# Altium schematic sheet [4]-USB.SchDoc
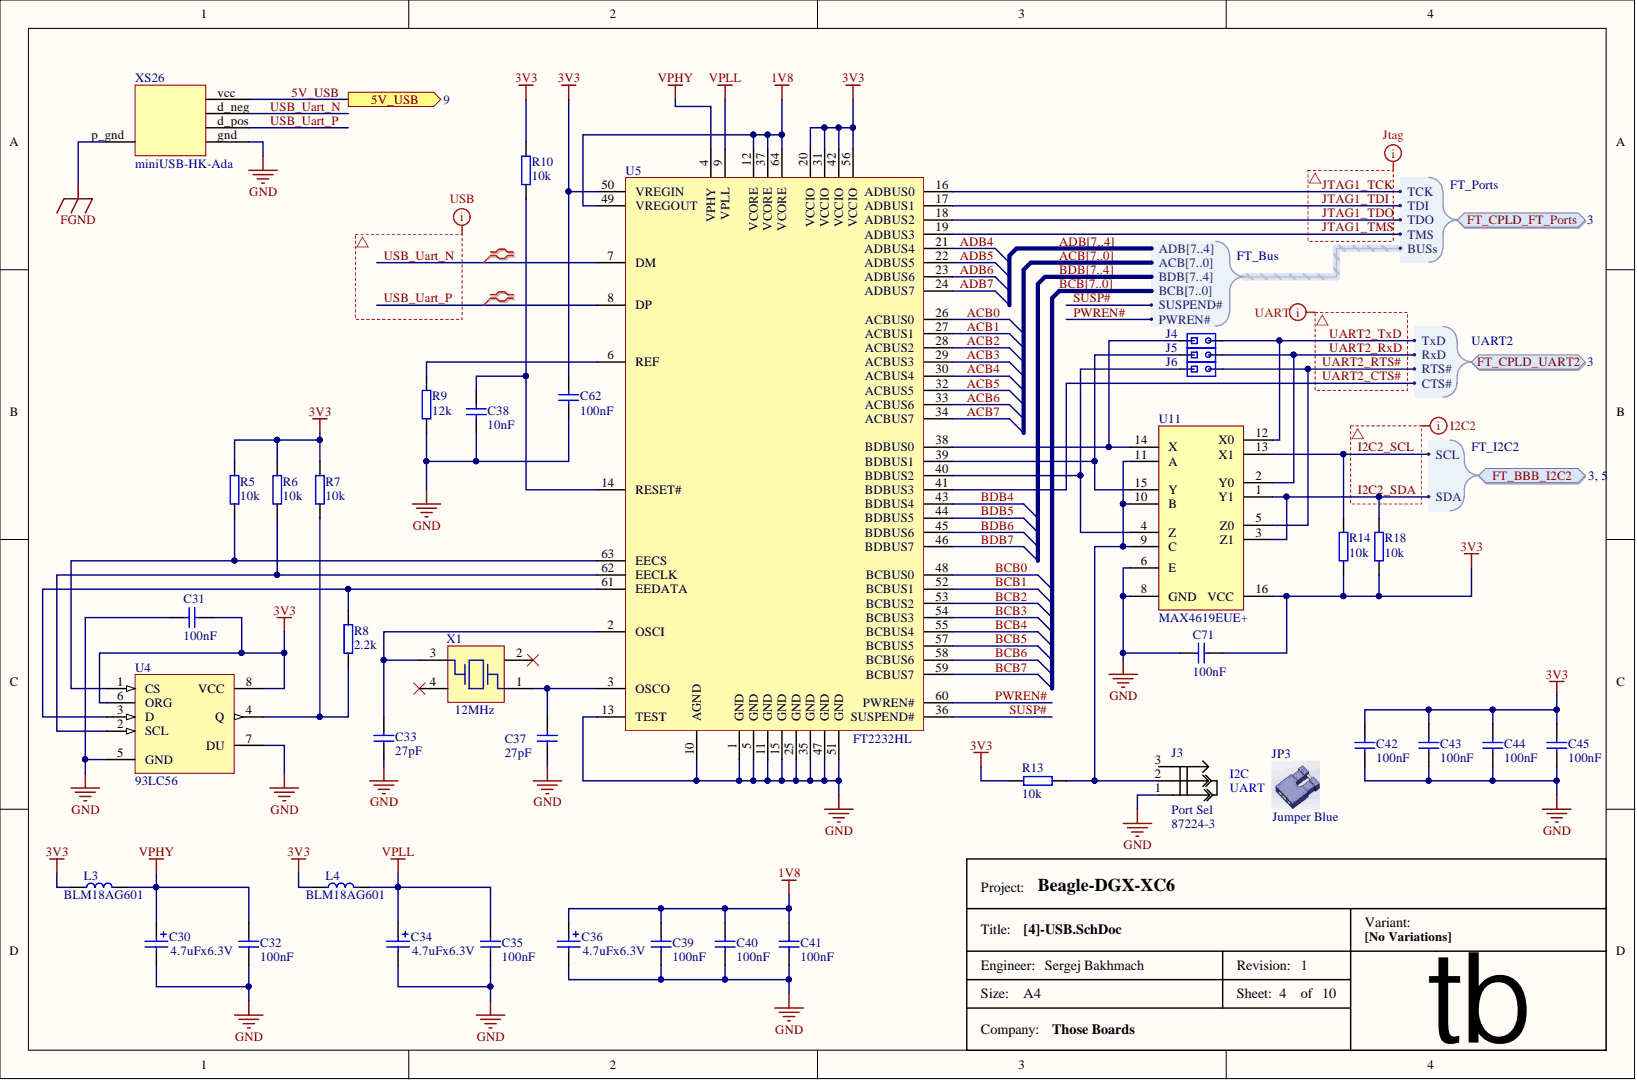
[diagram p0: [4]-USB.SchDoc]

## Parts
C30  value 4.7uFx6.3V  [CAP TAN 0805 2012 SIZE R]  (hidden comment: uF)
C31  value 100nF  [C0603]  (hidden comment: CAP)
C32  value 100nF  [C0603]  (hidden comment: CAP)
C33  value 27pF  [C0603]  (hidden comment: CAP)
C34  value 4.7uFx6.3V  [CAP TAN 0805 2012 SIZE R]  (hidden comment: uF)
C35  value 100nF  [C0603]  (hidden comment: CAP)
C36  value 4.7uFx6.3V  [CAP TAN 0805 2012 SIZE R]  (hidden comment: uF)
C37  value 27pF  [C0603]  (hidden comment: CAP)
C38  value 10nF  [C0603]  (hidden comment: CAP)
C39  value 100nF  [C0603]  (hidden comment: CAP)
C40  value 100nF  [C0603]  (hidden comment: CAP)
C41  value 100nF  [C0603]  (hidden comment: CAP)
C42  value 100nF  [C0603]  (hidden comment: CAP)
C43  value 100nF  [C0603]  (hidden comment: CAP)
C44  value 100nF  [C0603]  (hidden comment: CAP)
C45  value 100nF  [C0603]  (hidden comment: CAP)
C62  value 100nF  [C0603]  (hidden comment: CAP)
C71  value 100nF  [C0603]  (hidden comment: CAP)
J3  Port Sel  value 87224-3  Manufacturer Part: 87224-3  [Jumper_3pin]
J4  (no value)  [Jumper_smd_d3]  (no BOM)
J5  (no value)  [Jumper_smd_d3]  (no BOM)
J6  (no value)  [Jumper_smd_d3]  (no BOM)
JP3  value Jumper Blue  Supplier Part: Jumper  (hidden comment: VCC_sel)  (mechanical)
L3  value BLM18AG601  [ferrite_bead_0603]  (hidden comment: BLM18PG221SN1D)
L4  value BLM18AG601  [ferrite_bead_0603]  (hidden comment: BLM18PG221SN1D)
R5  value 10k  [0603_RESISTOR]  (hidden comment: Resistor)
R6  value 10k  [0603_RESISTOR]  (hidden comment: Resistor)
R7  value 10k  [0603_RESISTOR]  (hidden comment: Resistor)
R8  value 2.2k  [0603_RESISTOR]  (hidden comment: Resistor)
R9  value 12k  [0603_RESISTOR]  (hidden comment: Resistor)
R10  value 10k  [0603_RESISTOR]  (hidden comment: Resistor)
R13  value 10k  [0603_RESISTOR]  (hidden comment: Resistor)
R14  value 10k  [0603_RESISTOR]  (hidden comment: Resistor)
R18  value 10k  [0603_RESISTOR]  (hidden comment: Resistor)
U4  value 93LC56  [SOIC-8 150 Mil]  (hidden comment: 93xCxx)
U5  FT2232HL  [LQFP64]
U11  MAX4619EUE+  [TSSOP-16]
X1  value 12MHz  [OSC_CX3225GB]  (hidden comment: OSC_CX3225GB)
XS26  value miniUSB-HK-Ada  Manufacturer Part: miniUSB-HK-Ada  [MiniUSB_Conn_2types]  (hidden comment: miniUSB_conn)

## Ports
5V_USB, FT_BBB_I2C2, FT_BBB_I2C2.SCL, FT_BBB_I2C2.SDA, FT_CPLD_FT_Ports, FT_CPLD_FT_PORTS.BUSS.ACB0, FT_CPLD_FT_PORTS.BUSS.ACB1, FT_CPLD_FT_PORTS.BUSS.ACB2, FT_CPLD_FT_PORTS.BUSS.ACB3, FT_CPLD_FT_PORTS.BUSS.ACB4, FT_CPLD_FT_PORTS.BUSS.ACB5, FT_CPLD_FT_PORTS.BUSS.ACB6, FT_CPLD_FT_PORTS.BUSS.ACB7, FT_CPLD_FT_Ports.BUSs.ACB[7..0], FT_CPLD_FT_PORTS.BUSS.ADB4, FT_CPLD_FT_PORTS.BUSS.ADB5, FT_CPLD_FT_PORTS.BUSS.ADB6, FT_CPLD_FT_PORTS.BUSS.ADB7, FT_CPLD_FT_Ports.BUSs.ADB[7..4], FT_CPLD_FT_PORTS.BUSS.BCB0, FT_CPLD_FT_PORTS.BUSS.BCB1, FT_CPLD_FT_PORTS.BUSS.BCB2, FT_CPLD_FT_PORTS.BUSS.BCB3, FT_CPLD_FT_PORTS.BUSS.BCB4, FT_CPLD_FT_PORTS.BUSS.BCB5, FT_CPLD_FT_PORTS.BUSS.BCB6, FT_CPLD_FT_PORTS.BUSS.BCB7, FT_CPLD_FT_Ports.BUSs.BCB[7..0], FT_CPLD_FT_PORTS.BUSS.BDB4, FT_CPLD_FT_PORTS.BUSS.BDB5, FT_CPLD_FT_PORTS.BUSS.BDB6, FT_CPLD_FT_PORTS.BUSS.BDB7, FT_CPLD_FT_Ports.BUSs.BDB[7..4], FT_CPLD_FT_Ports.BUSs.PWREN#, FT_CPLD_FT_Ports.BUSs.SUSPEND#, FT_CPLD_FT_Ports.TCK, FT_CPLD_FT_Ports.TDI, FT_CPLD_FT_Ports.TDO, FT_CPLD_FT_Ports.TMS, FT_CPLD_UART2, FT_CPLD_UART2.CTS#, FT_CPLD_UART2.RTS#, FT_CPLD_UART2.RxD, FT_CPLD_UART2.TxD

## Nets
1V8: C36.1 C39.2 C40.2 C41.2 U5.12(VCORE) U5.37(VCORE) U5.49(VREGOUT) U5.64(VCORE)
3V3: C31.1 C42.2 C43.2 C44.2 C45.2 C62.2 C71.1 L3.1 L4.1 R5.2 R6.2 R7.2 R10.2 R13.2 R14.1 R18.1 U4.6(ORG) U4.8(VCC) U5.20(VCCIO) U5.31(VCCIO) U5.42(VCCIO) U5.50(VREGIN) U5.56(VCCIO) U11.16(VCC)
FGND: XS26.p_gnd
GND: C30.2 C31.2 C32.1 C33.1 C34.2 C35.1 C36.2 C37.1 C38.1 C39.1 C40.1 C41.1 C42.1 C43.1 C44.1 C45.1 C62.1 C71.2 J3.1 R9.1 U4.5(GND) U4.7(DU) U5.1(GND) U5.5(GND) U5.10(AGND) U5.11(GND) U5.13(TEST) U5.15(GND) U5.25(GND) U5.35(GND) U5.47(GND) U5.51(GND) U11.6(E) U11.8(GND) XS26.gnd
VPHY: C30.1 C32.2 L3.2 U5.4(VPHY)
VPLL: C34.1 C35.2 L4.2 U5.9(VPLL)
5V_USB: XS26.vcc ; port 5V_USB
ACB0: U5.26(ACBUS0) ; port FT_CPLD_FT_Ports  [also labelled ACB]
ACB1: U5.27(ACBUS1) ; port FT_CPLD_FT_Ports  [also labelled ACB]
ACB2: U5.28(ACBUS2) ; port FT_CPLD_FT_Ports  [also labelled ACB]
ACB3: U5.29(ACBUS3) ; port FT_CPLD_FT_Ports  [also labelled ACB]
ACB4: U5.30(ACBUS4) ; port FT_CPLD_FT_Ports  [also labelled ACB]
ACB5: U5.32(ACBUS5) ; port FT_CPLD_FT_Ports  [also labelled ACB]
ACB6: U5.33(ACBUS6) ; port FT_CPLD_FT_Ports  [also labelled ACB]
ACB7: U5.34(ACBUS7) ; port FT_CPLD_FT_Ports  [also labelled ACB]
ADB4: U5.21(ADBUS4) ; port FT_CPLD_FT_Ports  [also labelled ADB]
ADB5: U5.22(ADBUS5) ; port FT_CPLD_FT_Ports  [also labelled ADB]
ADB6: U5.23(ADBUS6) ; port FT_CPLD_FT_Ports  [also labelled ADB]
ADB7: U5.24(ADBUS7) ; port FT_CPLD_FT_Ports  [also labelled ADB]
BCB0: U5.48(BCBUS0) ; port FT_CPLD_FT_Ports  [also labelled BCB]
BCB1: U5.52(BCBUS1) ; port FT_CPLD_FT_Ports  [also labelled BCB]
BCB2: U5.53(BCBUS2) ; port FT_CPLD_FT_Ports  [also labelled BCB]
BCB3: U5.54(BCBUS3) ; port FT_CPLD_FT_Ports  [also labelled BCB]
BCB4: U5.55(BCBUS4) ; port FT_CPLD_FT_Ports  [also labelled BCB]
BCB5: U5.57(BCBUS5) ; port FT_CPLD_FT_Ports  [also labelled BCB]
BCB6: U5.58(BCBUS6) ; port FT_CPLD_FT_Ports  [also labelled BCB]
BCB7: U5.59(BCBUS7) ; port FT_CPLD_FT_Ports  [also labelled BCB]
BDB4: U5.43(BDBUS4) ; port FT_CPLD_FT_Ports  [also labelled BDB]
BDB5: U5.44(BDBUS5) ; port FT_CPLD_FT_Ports  [also labelled BDB]
BDB6: U5.45(BDBUS6) ; port FT_CPLD_FT_Ports  [also labelled BDB]
BDB7: U5.46(BDBUS7) ; port FT_CPLD_FT_Ports  [also labelled BDB]
I2C2_SCL: R14.2 U11.13(X1) ; port FT_BBB_I2C2
I2C2_SDA: R18.2 U11.1(Y1) U11.3(Z1) ; port FT_BBB_I2C2
JTAG1_TCK: U5.16(ADBUS0) ; port FT_CPLD_FT_Ports
JTAG1_TDI: U5.17(ADBUS1) ; port FT_CPLD_FT_Ports
JTAG1_TDO: U5.18(ADBUS2) ; port FT_CPLD_FT_Ports
JTAG1_TMS: U5.19(ADBUS3) ; port FT_CPLD_FT_Ports
PWREN#: U5.60(PWREN#) ; port FT_CPLD_FT_Ports
SUSP#: U5.36(SUSPEND#) ; port FT_CPLD_FT_Ports
UART2_CTS#: U5.41(BDBUS3) ; port FT_CPLD_UART2
UART2_RTS#: J6.2 U11.5(Z0) ; port FT_CPLD_UART2
UART2_RxD: J5.2 U11.2(Y0) ; port FT_CPLD_UART2
UART2_TxD: J4.2 U11.12(X0) ; port FT_CPLD_UART2
USB_Uart_N: U5.7(DM) XS26.d_neg
USB_Uart_P: U5.8(DP) XS26.d_pos
NetC33_2: C33.2 U5.2(OSCI) X1.3
NetC37_2: C37.2 U5.3(OSCO) X1.1
NetC38_2: C38.2 R10.1 U5.14(RESET#)
NetJ3_2: J3.2 R13.1 U11.9(C) U11.10(B) U11.11(A)
NetJ4_1: J4.1 U5.38(BDBUS0) U11.14(X)
NetJ5_1: J5.1 U5.39(BDBUS1) U11.15(Y)
NetJ6_1: J6.1 U5.40(BDBUS2) U11.4(Z)
NetR5_1: R5.1 U4.1(CS) U5.63(EECS)
NetR6_1: R6.1 U4.2(SCL) U5.62(EECLK)
NetR7_1: R7.1 R8.1 U4.4(Q)
NetR8_2: R8.2 U4.3(D) U5.61(EEDATA)
NetR9_2: R9.2 U5.6(REF)
N00235:
N00236:
N00237:
N00238:

Not connected: J3 3; X1 2 4

## Library data of the parts
C30 C34 C36 = Cap_pol_tant: Manufacturer: AVX; Manufacturer Part: TAJR475K006RNJ; Type of Component: Tantalum Capacitor; Component Kind: Standard; Manufacturer Link: https://www.avx.com/prodinfo_productdetail.asp?I=81&K=search&C=&word=TAJR475K006RNJ&form=number&Number=; Package / Case: 0805; Supplier: mouser; Supplier Link: http://www.mouser.com/ProductDetail/AVX/TAJR475K006RNJ/?qs=sGAEpiMZZMuEN2agSAc2pmRv4r8cj6yFV16HxCaO%252bYg%3d; Supplier Part: 581-TAJR475K006RNJ; Library Name: RPI-VITA.IntLib
C31 C32 C35 C39-C45 C62 C71 = CAP: Manufacturer: Vishay / Vitramon; Manufacturer Part: VJ0603Y104JXJPW1BC; Type of Component: Ceramic Capacitor; Component Kind: Standard; Manufacturer Link: http://www.vishay.com/product?docid=28548; Package / Case: 0603; Supplier: mouser; Supplier Link: http://www.mouser.com/ProductDetail/Vishay/VJ0603Y104JXJPW1BC/?qs=%2fha2pyFaduidGoO0X7aK7Xgs%2fjxfhnLiK6SRw4Lz0%252bZK3PJ8DddTrQ%3d%3d; Supplier Part: 77-VJ0603Y104JXJPBC; Library Name: RPI-VITA.IntLib
C33 C37 = CAP: Manufacturer: Vishay / Vitramon; Manufacturer Part: VJ0603A270GXAPW1BC; Type of Component: Ceramic Capacitor; Component Kind: Standard; Manufacturer Link: http://www.vishay.com/product?docid=28548; Package / Case: 0603; Supplier: mouser; Supplier Link: http://www.mouser.com/ProductDetail/Vishay-Vitramon/VJ0603A270GXAPW1BC/?qs=sGAEpiMZZMs0AnBnWHyRQCv4vfTUG%2fvHq9VjSt3ox9g%3d; Supplier Part: 77-VJ0603A270GXAPBC; Library Name: RPI-VITA.IntLib
C38 = CAP: Manufacturer: Vishay / Vitramon; Manufacturer Part: VJ0603Y103KXACW1BC; Type of Component: Ceramic Capacitor; Component Kind: Standard; Manufacturer Link: http://www.vishay.com/product?docid=28548; Package / Case: 0603; Supplier: mouser; Supplier Link: http://www.mouser.com/ProductDetail/Vishay-Vitramon/VJ0603Y103KXACW1BC/?qs=sGAEpiMZZMs0AnBnWHyRQNbg85K4ab%2f3XqRx2jQHGsc%3d; Supplier Part: 77-VJ0603Y103KXACBC; Library Name: RPI-VITA.IntLib
J3 = jumper_3pin: Manufacturer: TE Connectivity / AMP; Type of Component: Jumper; Component Kind: Standard; Manufacturer Link: http://www.te.com/usa-en/product-87224-3.html#pdp-related-products-inner; Package / Case: Header 1 x 3; Supplier: mouser; Supplier Link: http://www.mouser.ee/ProductDetail/TE-Connectivity-AMP/87224-3/?qs=sGAEpiMZZMs%252bGHln7q6pm48SVpWlpfsErguO%2fp0873w%3d; Supplier Part: 571-872243; Library Name: RPI-VITA.IntLib
J4-J6 = jumper_2pin_pads: Library Name: RPI-VITA.IntLib
JP3 = jumper blue: Manufacturer: Great Electric; Manufacturer Part: Jumper Cap Shunts Short Circuit Cap; Package / Case: 2.54mm; Supplier: aliexpress.com; Supplier Link: http://www.aliexpress.com/item/500pcs-lot-Asssorted-Color-2-54mm-Standard-Circuit-Board-Jumper-Cap-Shunts-Short-Circuit-Cap-CGKCH119/32344305619.html?spm=2114.03010208.3.80.nPPmoy&ws_ab_test=searchweb201556_1,searchweb201644_1_505_506_503_504_502_10001_10002_10017_10010_10005_10011_10006_10003_10004_10009_10007_10008,searchweb201560_5,searchweb1451318400_-1,searchweb1451318411_6452&btsid=16d11777-edde-4755-b8b5-9adacb495b09; Type of Component: Jumper; Library Name: RPI-VITA.IntLib
L3 L4 = BLM18PG221SN1D: ferrite bead 0603; Manufacturer: Murata; Manufacturer Part: BLM18AG601SN1D; Type of Component: EMI Filter Beads; Component Kind: Standard; Manufacturer Link: http://search.murata.co.jp/Ceramy/CatalogAction.do?sHinnm=BLM11A601S&sNHinnm=BLM18AG601SN1&sNhin_key=BLM18AG601SN1D&sLang=en&sParam=BLM18AG601SN1D; Package / Case: 0603; Supplier: mouser; Supplier Link: http://www.mouser.com/ProductDetail/Murata/BLM18AG601SN1D/?qs=%2fha2pyFadugaXrdTm9z6ujoHK9ziqVaZDl9lDPSbK0ptDeQWgq1exg%3d%3d; Supplier Part: 81-BLM11A601S; Library Name: RPI-VITA.IntLib
R5-R7 R10 R13 R14 R18 = Resistor: Manufacturer: Vishay / Dale; Manufacturer Part: CRCW060310K0FKEA; Component Kind: Standard; Manufacturer Link: http://www.vishay.com/product?docid=20035; Package / Case: 0603; Supplier: mouser; Supplier Link: http://www.mouser.com/ProductDetail/Vishay-Dale/CRCW060310K0FKEA/?qs=sGAEpiMZZMu61qfTUdNhGyptJL5EcUtREGTsEKG7Lak%3d; Supplier Part: 71-CRCW0603-10K-E3; Type of Component: Resistor; Library Name: RPI-VITA.IntLib
R8 = Resistor: Manufacturer: Vishay / Dale; Manufacturer Part: CRCW06032K20JNEA; Component Kind: Standard; Manufacturer Link: http://www.vishay.com/product?docid=20035; Package / Case: 0603; Supplier: mouser; Supplier Link: http://www.mouser.com/ProductDetail/Vishay-Dale/CRCW06032K20JNEA/?qs=sGAEpiMZZMvdGkrng054txEw7b1YnvGuRJLBQI6feSk%3d; Supplier Part: 71-CRCW0603J-2.2K-E3; Type of Component: Resistor; Library Name: RPI-VITA.IntLib
R9 = Resistor: Manufacturer: Vishay / Dale; Manufacturer Part: CRCW060312K0FKEA; Component Kind: Standard; Manufacturer Link: http://www.vishay.com/product?docid=20035; Package / Case: 0603; Supplier: mouser; Supplier Link: http://www.mouser.com/ProductDetail/Vishay-Dale/CRCW060312K0FKEA/?qs=sGAEpiMZZMu61qfTUdNhG%2fy1ga03FwuG5%2fz6Ysnsyas%3d; Supplier Part: 71-CRCW0603-12K-E3; Type of Component: Resistor; Library Name: RPI-VITA.IntLib
U4 = 93xCxx: eeprom; Manufacturer: Microchip Technology; Manufacturer Part: 93LC56CT-I/SN; Component Kind: Standard; Manufacturer Link: http://www.microchip.com/wwwproducts/Devices.aspx?product=93LC56C; Package / Case: SOIC-8; Supplier: mouser; Supplier Link: http://www.mouser.com/ProductDetail/Microchip-Technology/93LC56CT-I-SN/?qs=sGAEpiMZZMuVhdAcoizlRTtWxdtwgs5NlmtRRTBFNKE%3d; Supplier Part: 579-93LC56CT-I/SN; Type of Component: EEPROM; Library Name: RPI-VITA.IntLib
U5 = FT2232HL: Manufacturer: FTDI; Manufacturer Part: FT2232HL-TRAY; Type of Component: USB Interface IC; Component Kind: Standard; Manufacturer Link: http://www.ftdichip.com/Products/ICs/FT2232H.htm; Package / Case: LQFP-64; Supplier: mouser; Supplier Link: http://www.mouser.com/ProductDetail/FTDI/FT2232HL-TRAY/?qs=sGAEpiMZZMtv%252bwxsgy%2fhiMKIrlJio50yoVwto4siX0c%3d; Supplier Part: 895-FT2232HL-TRAY; Value: FT2232HL; Library Name: RPI-VITA.IntLib
U11 = MAX4619EUE+: High-Speed, Low-Voltage, CMOS Analog  Multiplexers/Switches; Manufacturer: Maxim Integrated; Type of Component: Analog Switch IC; Component Kind: Standard; Manufacturer Link: https://www.maximintegrated.com/en/products/analog/analog-switches-multiplexers/MAX4619.html; Manufacturer Part: MAX4619EUE+; Package / Case: TSSOP-16; Supplier: mouser; Supplier Link: http://www2.mouser.com/ProductDetail/Maxim-Integrated/MAX4619EUE+/?qs=sGAEpiMZZMvjbjwkTuU2aa02s6X86Mg5lA6G81ZX%252b98%3d; Supplier Part: 700-MAX4619EUE+; Value: MAX4619EUE+; Library Name: RPI-VITA.IntLib
X1 = OSC_CX3225GB: Manufacturer: Kyocera; Manufacturer Part: CX3225GB12000P0HPQCC; Type of Component: Crystal Quartz; Component Kind: Standard; Manufacturer Link: http://www.kyocera-crystal.jp/eng/prdct/list/xtal/; Package / Case: 3.2 mm x 2.5 mm; Supplier: mouser; Supplier Link: http://www.mouser.com/ProductDetail/AVX/CX3225GB12000P0HPQCC/?qs=sGAEpiMZZMsBj6bBr9Q9aY2qtJ4G5VulKoMshKcCM6ORlbreQkoE2A%3d%3d; Supplier Part: 581-CX3225GB12PHPQCC; Library Name: RPI-VITA.IntLib
XS26 = miniUSB_conn: mini USB Connector; Manufacturer: HK-Ada; Type of Component: Connector miniUSB; Component Kind: Standard; Package / Case: miniUSB; Supplier: HK-Ada; Library Name: RPI-VITA.IntLib
